# Altium schematic sheet Ind_current_sensor_U.SchDoc
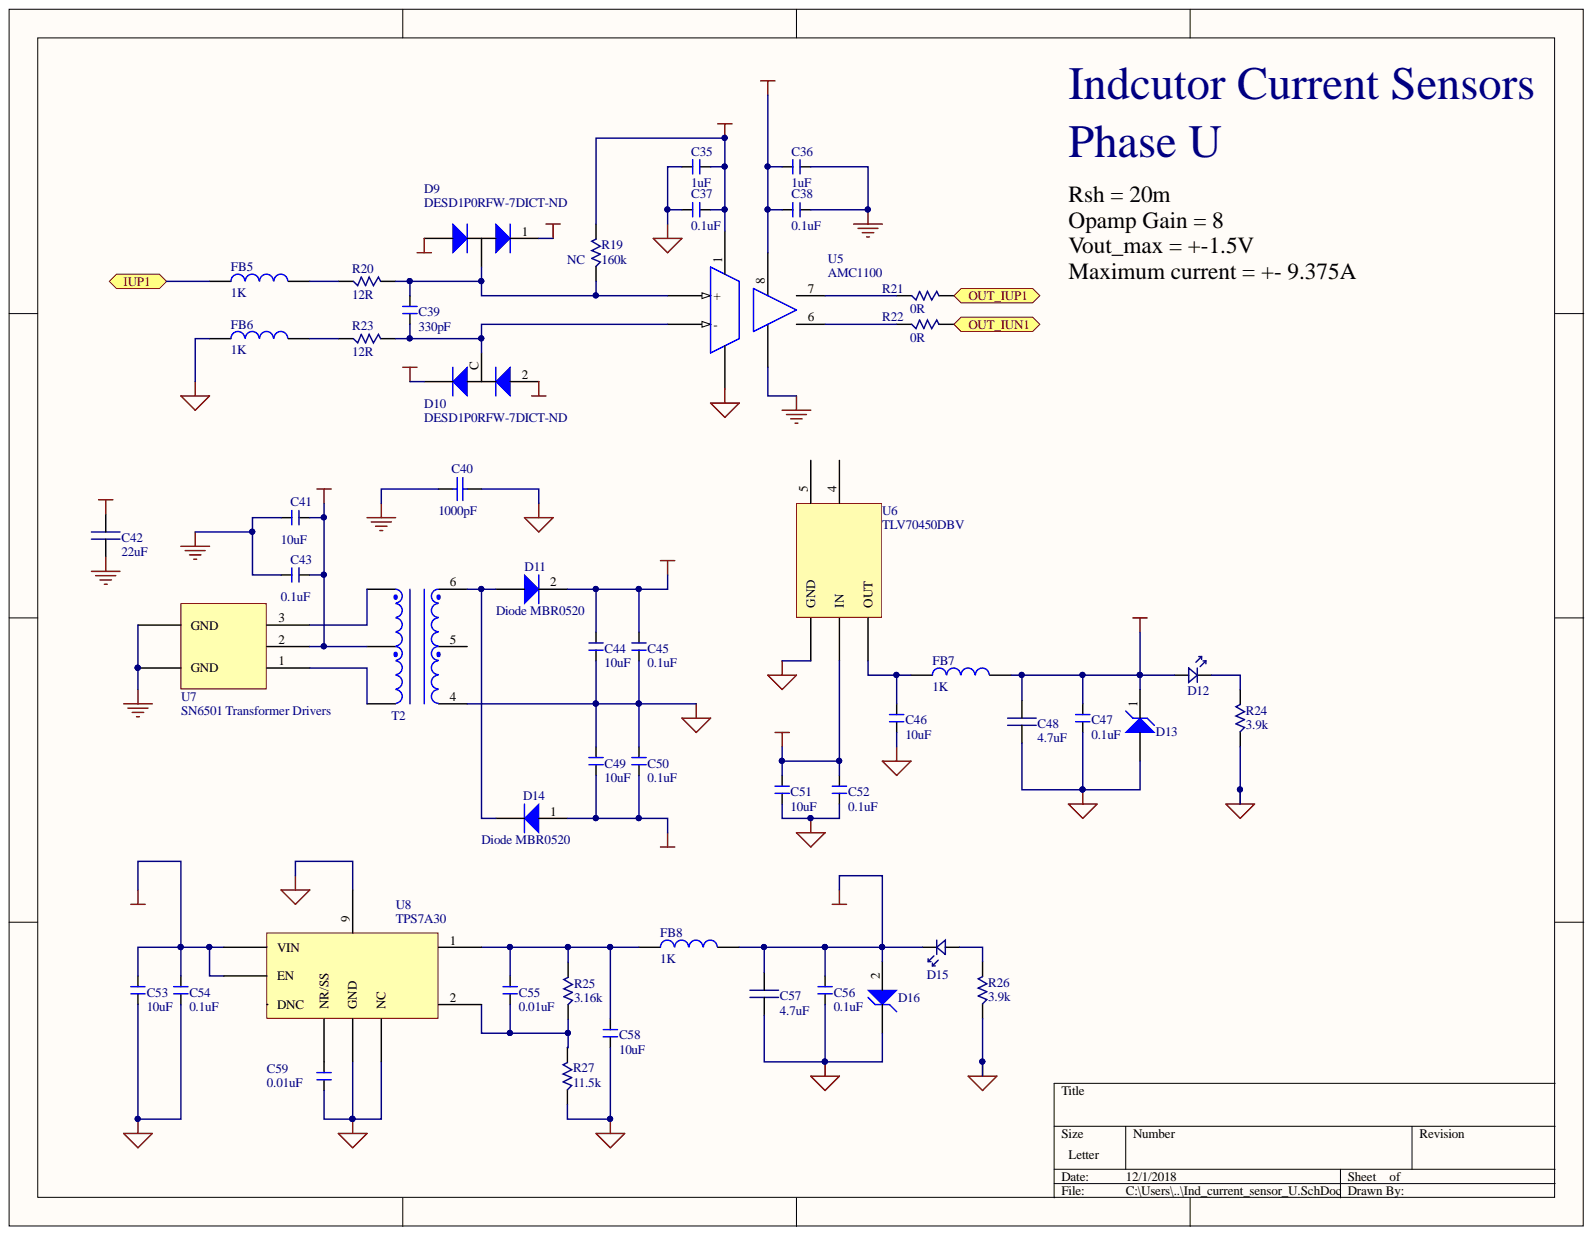
[diagram p0: Ind_current_sensor_U.SchDoc]

## Parts
C35  value 1uF  [0603 (1608 Metric)]  (hidden comment: Cap 0603_new)
C36  value 1uF  [0603 (1608 Metric)]  (hidden comment: Cap 0603_new)
C37  value 0.1uF  [0603 (1608 Metric)]  (hidden comment: Cap 0603_new)
C38  value 0.1uF  [0603 (1608 Metric)]  (hidden comment: Cap 0603_new)
C39  value 330pF  [0603 (1608 Metric)]  (hidden comment: Cap 0603_new)
C40  value 1000pF  [RAD-0.3]  (hidden comment: Cap TH)
C41  value 10uF  [0603 (1608 Metric)]  (hidden comment: Cap 0603_new)
C42  value 22uF  [0805 (2012 metric)]  (hidden comment: Cap 0805)
C43  value 0.1uF  [0603 (1608 Metric)]  (hidden comment: Cap 0603_new)
C44  value 10uF  [0603 (1608 Metric)]  (hidden comment: Cap 0603_new)
C45  value 0.1uF  [0603 (1608 Metric)]  (hidden comment: Cap 0603_new)
C46  value 10uF  [0603 (1608 Metric)]  (hidden comment: Cap 0603_new)
C47  value 0.1uF  [0603 (1608 Metric)]  (hidden comment: Cap 0603_new)
C48  value 4.7uF  [0805 (2012 metric)]  (hidden comment: Cap 0805)
C49  value 10uF  [0603 (1608 Metric)]  (hidden comment: Cap 0603_new)
C50  value 0.1uF  [0603 (1608 Metric)]  (hidden comment: Cap 0603_new)
C51  value 10uF  [0603 (1608 Metric)]  (hidden comment: Cap 0603_new)
C52  value 0.1uF  [0603 (1608 Metric)]  (hidden comment: Cap 0603_new)
C53  value 10uF  [0603 (1608 Metric)]  (hidden comment: Cap 0603_new)
C54  value 0.1uF  [0603 (1608 Metric)]  (hidden comment: Cap 0603_new)
C55  value 0.01uF  [0603 (1608 Metric)]  (hidden comment: Cap 0603_new)
C56  value 0.1uF  [0603 (1608 Metric)]  (hidden comment: Cap 0603_new)
C57  value 4.7uF  [0805 (2012 metric)]  (hidden comment: Cap 0805)
C58  value 10uF  [0603 (1608 Metric)]  (hidden comment: Cap 0603_new)
C59  value 0.01uF  [0603 (1608 Metric)]  (hidden comment: Cap 0603_new)
D9  Part: DESD1P0RFW-7DICT-ND  [SOT323_ESD]  (hidden comment: TVS - Diodes)
D10  Part: DESD1P0RFW-7DICT-ND  [SOT323_ESD]  (hidden comment: TVS - Diodes)
D11  Diode MBR0520  [SOD-123]
D12  LED  [0805 (2012 Metric) Diode]  (value not drawn)
D13  (no value)  [POWERDI]
D14  Diode MBR0520  [SOD-123]
D15  LED  [0805 (2012 Metric) Diode]  (value not drawn)
D16  (no value)  [POWERDI]
FB5  value 1K  [0603 (1608 Metric)]  (hidden comment: Ferrite bead)
FB6  value 1K  [0603 (1608 Metric)]  (hidden comment: Ferrite bead)
FB7  value 1K  [0603 (1608 Metric)]  (hidden comment: Ferrite bead)
FB8  value 1K  [0603 (1608 Metric)]  (hidden comment: Ferrite bead)
R19  NC  value 160k  [0603 (1608 Metric)]
R20  value 12R  [1206]  (hidden comment: Resistor 1206)
R21  value 0R  [0603 (1608 Metric)]  (hidden comment: Resistor 0603)
R22  value 0R  [0603 (1608 Metric)]  (hidden comment: Resistor 0603)
R23  value 12R  [1206]  (hidden comment: Resistor 1206)
R24  value 3.9k  [0603 (1608 Metric)]  (hidden comment: Resistor 0603)
R25  value 3.16k  [0603 (1608 Metric)]  (hidden comment: Resistor 0603)
R26  value 3.9k  [0603 (1608 Metric)]  (hidden comment: Resistor 0603)
R27  value 11.5k  [0603 (1608 Metric)]  (hidden comment: Resistor 0603)
T2  750313769  [Xmr SN6501]  (value not drawn)
U5  AMC1100  [SOIC8]
U6  TLV70450DBV  [SOT23-5]
U7  SN6501 Transformer Drivers  [SOT23-5]
U8  TPS7A30  [8-TSSOP]

## Ports
IUP1, OUT_IUN1, OUT_IUP1

## Nets
+5V_LV: C36.1 C38.1 C41.2 C42.2 C43.2 T2.2 U5.8 U7.2
+5V_USH1: C35.2 C37.2 C47.2 C48.2 D9.1 D10.1 D12.A D13.1 FB7.2 R19.2 U5.1
+6V_USH1: C44.2 C45.2 C51.2 C52.2 D11.2 U6.2(IN)
-5V_USH1: C56.2 C57.2 D9.2 D10.2 D15.K D16.2 FB8.2
-6V_USH1: C49.1 C50.1 C53.2 C54.2 D14.1 U8.5(EN) U8.8(VIN)
GND_LV: C36.2 C38.2 C40.1 C41.1 C42.1 C43.1 U5.5 U7.4(GND) U7.5(GND)
GND_USH1: C35.1 C37.1 C40.2 C44.1 C45.1 C46.1 C47.1 C48.1 C49.2 C50.2 C51.1 C52.1 C53.1 C54.1 C56.1 C57.1 C58.1 C59.1 D13.2 D16.1 FB6.1 R24.1 R26.1 R27.1 T2.4 U5.4 U6.1(GND) U8.3(NC) U8.4(GND) U8.9
IUP1: FB5.1 ; port IUP1
OUT_IUN1: R22.2 ; port OUT_IUN1
OUT_IUP1: R21.2 ; port OUT_IUP1
NetC39_1: C39.1 D9.C R19.1 R20.2 U5.2(+)
NetC39_2: C39.2 D10.C R23.2 U5.3(-)
NetC46_2: C46.2 FB7.1 U6.3(OUT)
NetC55_1: C55.1 C58.2 FB8.1 R25.2 U8.1
NetC55_2: C55.2 R25.1 R27.2 U8.2
NetC59_2: C59.2 U8.6(NR/SS)
NetD11_1: D11.1 D14.2 T2.6
NetD12_K: D12.K R24.2
NetD15_A: D15.A R26.2
NetFB5_2: FB5.2 R20.1
NetFB6_2: FB6.2 R23.1
NetR21_1: R21.1 U5.7
NetR22_1: R22.1 U5.6
NetT2_1: T2.1 U7.3
NetT2_3: T2.3 U7.1

Not connected: T2 5; U6 4 5; U8 7

## Library data of the parts
C35 C36 = Cap 0603_new: CAP CER 1UF 50V X5R 0603; part: 1276-1860-1-ND; Component Kind: Standard; Value: 1uF; Library Name: Library_Microgrid.SchLib
C37 C38 C43 C45 C47 C50 C52 C54 C56 = Cap 0603_new: CAP CER 0.1UF 50V Y5V 0603; part: 311-1343-6-ND; Component Kind: Standard; Library Name: Library_Microgrid.SchLib
  C43: Value: 0.1uF
C39 = Cap 0603_new: CAP CER 330PF 50V C0G 0603; part: 445-7033-2-ND; Component Kind: Standard; Library Name: Library_Microgrid.SchLib
C40 = Cap: CAP CER 1000PF 1KV Y5P RADIAL; Part: 1251PH-ND; Component Kind: Standard; LatestRevisionDate: 17-Jul-2002; LatestRevisionNote: Re-released for DXP Platform.; Library Name: Miscellaneous Devices.IntLib; PackageDescription: Radial Cap, Thru-Hole; 2 Leads; 0.3 in Pin Spacing; PackageReference: RAD-0.3; Published: 8-Jun-2000; Signal Integrity: Cap; Simulation: CAP; Publisher: Altium Limited
C41 C44 C46 C49 C51 C53 C58 = Cap 0603_new: CAP CER 10UF 35V X5R 0805; part: 490-10515-1-ND; Component Kind: Standard; Library Name: Library_Microgrid.SchLib
  C41: Value: 10uF
C42 = Cap 0805: CAP CER 22UF 35V X5R 0805; Part: 445-14428-1-ND; Component Kind: Standard; Library Name: Library_Microgrid.SchLib
C48 C57 = Cap 0805: CAP CER 4.7UF 50V X5R 0805; Part: 445-5980-1-ND; Component Kind: Standard; Library Name: Library_Microgrid.SchLib
C55 C59 = Cap 0603_new: CAP CER 10000PF 100V X7R 0603; part: 478-7418-1-ND; Component Kind: Standard; Library Name: Library_Microgrid.SchLib
D9 D10 = Diode_ESD: TVS DIODE 70VWM 8VC SOT323; Value: ESD; Component Kind: Standard; Library Name: Library_Microgrid.SchLib
D11 D14 = Diode MBR0520: DIODE SCHOTTKY 20V 500MA SOD123; Part: MBR0520LT1GOSCT-ND; Component Kind: Standard; Library Name: Library_Microgrid.SchLib
D12 D15 = LED: LED 0805; Component Kind: Standard; Library Name: Library_Microgrid.SchLib
D13 D16 = Diode Zener POWERDI123: Part: MMSZ5228B-FDICT-ND; Component Kind: Standard; Description: DIODE ZENER POWERDI123; Library Name: Library_Microgrid.SchLib
FB5-FB8 = Ferrite bead: FERRITE BEAD 1 KOHM 0603 1LN; Part: 445-8672-1-ND; Component Kind: Standard; Library Name: Library_Microgrid.SchLib
R19 = Resistor 0603: Component Kind: Standard; Description: Resistor 0603; Library Name: Library_Microgrid.SchLib
R20 R23 = Resistor 1206: 12 Ohm ±0.5% 0.25W, 1/4W Chip Resistor 1206; Part: 311-2961-1-ND; Component Kind: Standard; Library Name: Library_Microgrid.SchLib
R21 R22 = Resistor 0603: RES SMD 10 OHM 0.05% 1/10W 0603; Part: RNCF0603AKT10R0CT-ND; Component Kind: Standard; Library Name: Library_Microgrid.SchLib
R24 R26 = Resistor 0603: RES SMD 3.9K OHM 5% 1/10W 0603; Part: 541-3.9KGCT-ND; Component Kind: Standard; Library Name: Library_Microgrid.SchLib
R25 = Resistor 0603: RES SMD 3.16K OHM 1% 1/10W 0603; Part: 311-3.16KHRCT-ND; Component Kind: Standard; Library Name: Library_Microgrid.SchLib
R27 = Resistor 0603: RES SMD 11.5K OHM 1% 1/10W 0603; Part: 541-11.5KHCT-ND; Component Kind: Standard; Library Name: Library_Microgrid.SchLib
T2 = 750313769: TRANSFORMER SMD TR1.7 SN6501; Part: 1297-1107-1-ND; Component Kind: Standard; Library Name: Library_Microgrid.SchLib
U5 = AMC1100: IC OPAMP ISOLATION 100KHZ 8SOIC; Part: 296-38822-5-ND; Component Kind: Standard; Library Name: Library_Microgrid.SchLib
U6 = TLV70450DBV: IC REG LDO 5V 0.15A SOT23-5; Part: 296-39287-1-ND; Component Kind: Standard; Library Name: Library_Microgrid.SchLib
U7 = SN6501: IC REG CONV PWR SUPPLIES SOT23-5; Part: 296-37700-1-ND; Component Kind: Standard; Library Name: Library_Microgrid.SchLib
U8 = TPS7A30: IC REG LDO NEG ADJ 0.2A 8MSOP; Part: 296-27750-1-ND; Component Kind: Standard; Library Name: Library_Microgrid.SchLib
